# Altium schematic sheet Sheet1.SchDoc(Sheet1)
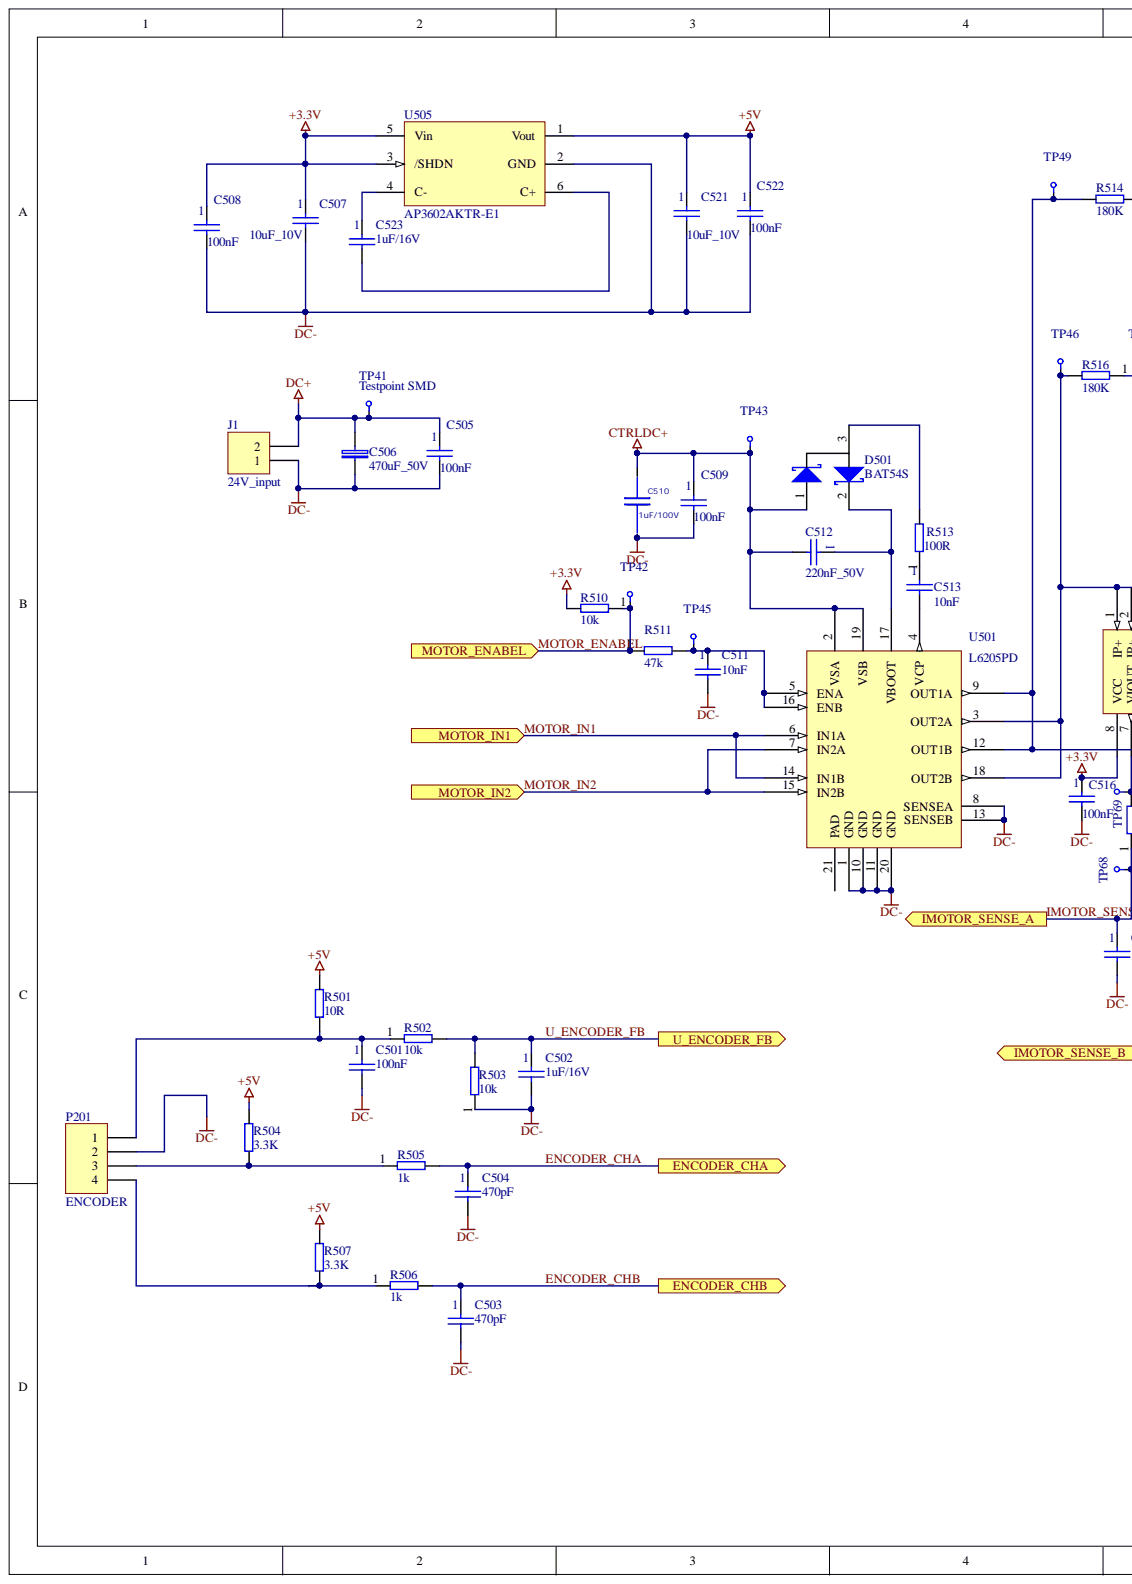
[diagram p0: Sheet1.SchDoc, left]
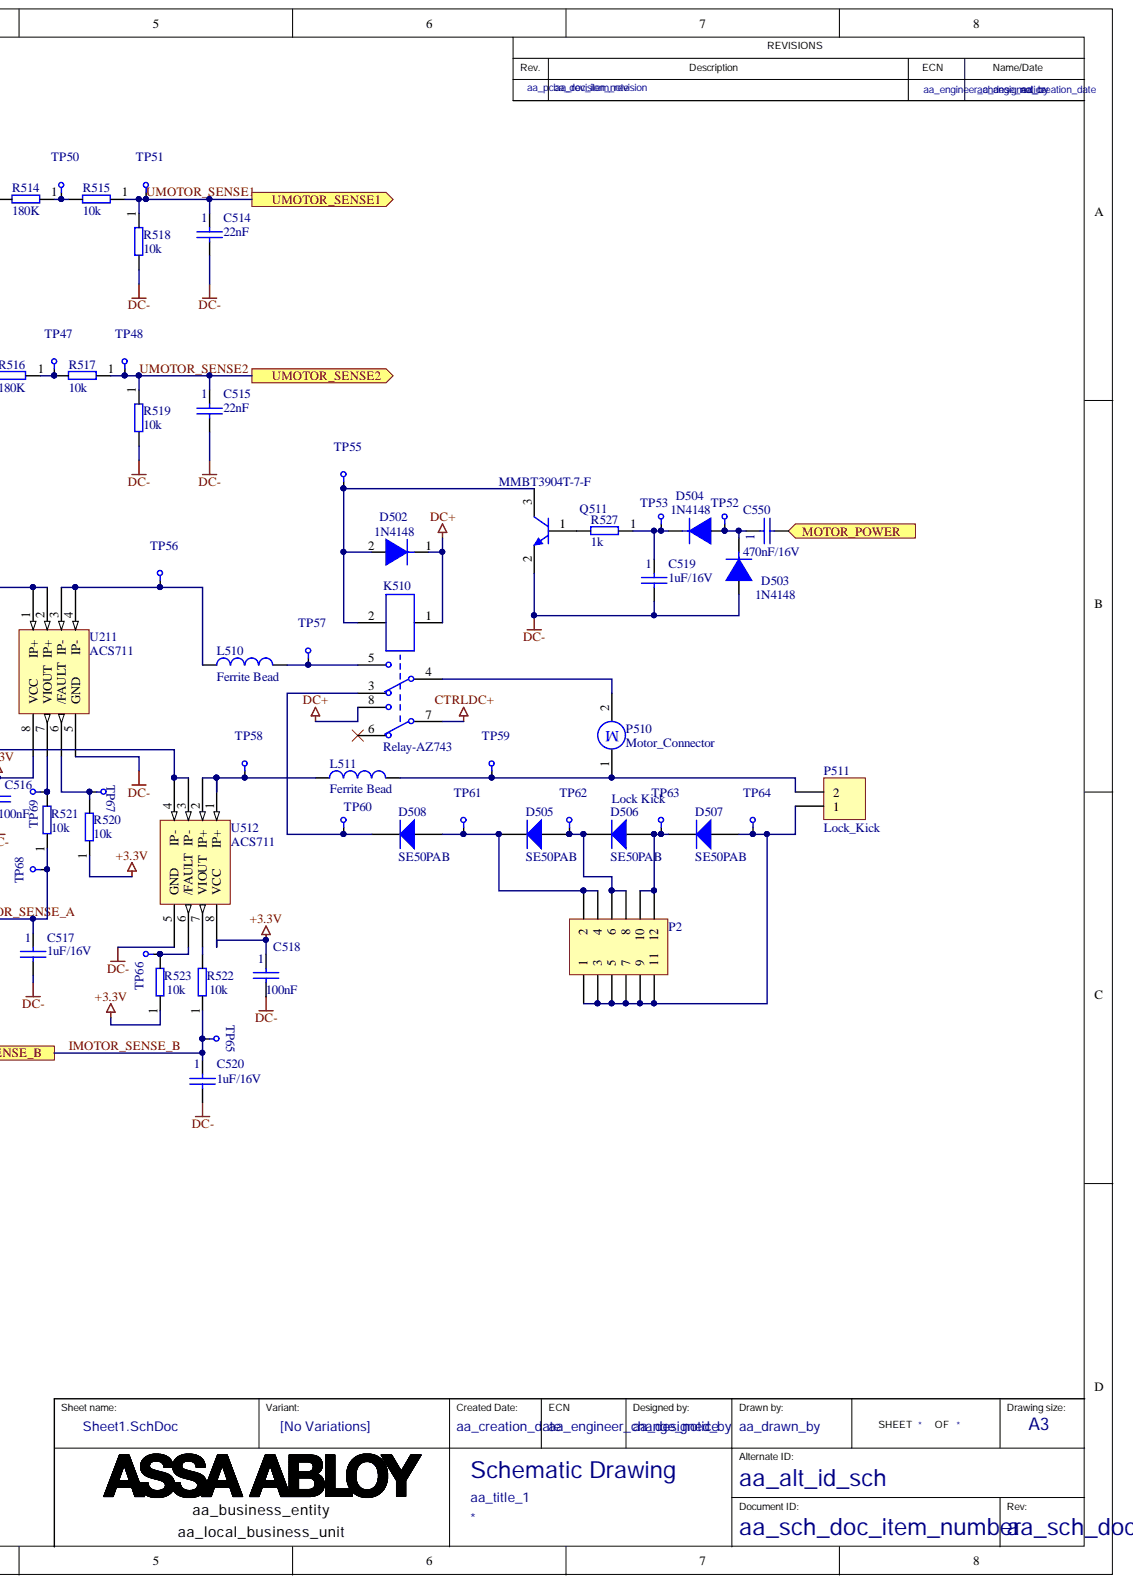
[diagram p1: Sheet1.SchDoc, right]

## Parts
C501  100nF  [CAPC1608X08N]
C502  1uF/16V  [CAPC1608X08N]
C503  470pF  [CAPC1608X08N]
C504  470pF  [CAPC1608X08N]
C505  100nF  [CAPC1608X08N]
C506  470uF_50V  [EC5/10]
C507  10uF_10V  [0603C/H]
C508  100nF  [CAPC1608X08N]
C509  100nF  [CAPC1608X08N]
C510  value 1uF/100V  [CAPR-RM7_5$5C$10_3X5_7]  (hidden comment: CAPR_RM7_5_1U/100V)
C511  10nF  [CAPC1608X08N]
C512  220nF_50V  [0603C/H]
C513  10nF  [CAPC1608X08N]
C514  22nF  [CAPC1608X08N]
C515  22nF  [CAPC1608X08N]
C516  100nF  [CAPC1608X08N]
C517  1uF/16V  [CAPC1608X08N]
C518  100nF  [CAPC1608X08N]
C519  1uF/16V  [CAPC1608X08N]
C520  1uF/16V  [CAPC1608X08N]
C521  10uF_10V  [0603C/H]
C522  100nF  [CAPC1608X08N]
C523  1uF/16V  [CAPC1608X08N]
C550  470nF/16V  [CAPC1608X08N]
D501  BAT54S  [SOT-23-3]
D502  1N4148  [SODFL250X110-2N]
D503  1N4148  [SODFL250X110-2N]
D504  1N4148  [SODFL250X110-2N]
D505  SE50PAB  [SMA-S1G]
D506  SE50PAB  [SMA-S1G]
D507  SE50PAB  [SMA-S1G]
D508  SE50PAB  [SMA-S1G]
J1  24V_input  [BP-VH-FB-B]
K510  Relay-AZ743  [Relay_AZ743]
L510  Ferrite Bead  [Ferrite_bead]
L511  Ferrite Bead  [Ferrite_bead]
P2  (no value)  [PCBComponent_1]
P201  ENCODER  [CON$5C$4P$5C$MICRO-F-1700566]
P510  Motor_Connector  [CON-TS-1X3-738667]
P511  Lock_Kick  [Molex_26-60-4020]
Q511  MMBT3904T-7-F  [SOT-523]
R501  10R  [RESC1608X05N]
R502  10k  [RESC1608X05N]
R503  10k  [RESC1608X05N]
R504  3.3K  [0603R/H]
R505  1k  [RESC1608X05N]
R506  1k  [RESC1608X05N]
R507  3.3K  [0603R/H]
R510  10k  [RESC1608X05N]
R511  47k  [RESC1608X05N]
R513  100R  [RESC1608X05N]
R514  180K  [0603R/H]
R515  10k  [RESC1608X05N]
R516  180K  [0603R/H]
R517  10k  [RESC1608X05N]
R518  10k  [RESC1608X05N]
R519  10k  [RESC1608X05N]
R520  10k  [RESC1608X05N]
R521  10k  [RESC1608X05N]
R522  10k  [RESC1608X05N]
R523  10k  [RESC1608X05N]
R527  1k  [RESC1608X05N]
TP41  Testpoint SMD  [PCB_PARTS_TESTPOINT100_SMD]  (no BOM)
TP42  Testpoint SMD  [PCB_PARTS_TESTPOINT100_SMD]  (no BOM)
TP43  Testpoint SMD  [PCB_PARTS_TESTPOINT100_SMD]  (no BOM)
TP45  Testpoint SMD  [PCB_PARTS_TESTPOINT100_SMD]  (no BOM)
TP46  Testpoint SMD  [PCB_PARTS_TESTPOINT100_SMD]  (no BOM)
TP47  Testpoint SMD  [PCB_PARTS_TESTPOINT100_SMD]  (no BOM)
TP48  Testpoint SMD  [PCB_PARTS_TESTPOINT100_SMD]  (no BOM)
TP49  Testpoint SMD  [PCB_PARTS_TESTPOINT100_SMD]  (no BOM)
TP50  Testpoint SMD  [PCB_PARTS_TESTPOINT100_SMD]  (no BOM)
TP51  Testpoint SMD  [PCB_PARTS_TESTPOINT100_SMD]  (no BOM)
TP52  Testpoint SMD  [PCB_PARTS_TESTPOINT100_SMD]  (no BOM)
TP53  Testpoint SMD  [PCB_PARTS_TESTPOINT100_SMD]  (no BOM)
TP55  Testpoint SMD  [PCB_PARTS_TESTPOINT100_SMD]  (no BOM)
TP56  Testpoint SMD  [PCB_PARTS_TESTPOINT100_SMD]  (no BOM)
TP57  Testpoint SMD  [PCB_PARTS_TESTPOINT100_SMD]  (no BOM)
TP58  Testpoint SMD  [PCB_PARTS_TESTPOINT100_SMD]  (no BOM)
TP59  Testpoint SMD  [PCB_PARTS_TESTPOINT100_SMD]  (no BOM)
TP60  Testpoint SMD  [PCB_PARTS_TESTPOINT100_SMD]  (no BOM)
TP61  Testpoint SMD  [PCB_PARTS_TESTPOINT100_SMD]  (no BOM)
TP62  Testpoint SMD  [PCB_PARTS_TESTPOINT100_SMD]  (no BOM)
TP63  Testpoint SMD  [PCB_PARTS_TESTPOINT100_SMD]  (no BOM)
TP64  Testpoint SMD  [PCB_PARTS_TESTPOINT100_SMD]  (no BOM)
TP65  Testpoint SMD  [PCB_PARTS_TESTPOINT100_SMD]  (no BOM)
TP66  Testpoint SMD  [PCB_PARTS_TESTPOINT100_SMD]  (no BOM)
TP67  Testpoint SMD  [PCB_PARTS_TESTPOINT100_SMD]  (no BOM)
TP68  Testpoint SMD  [PCB_PARTS_TESTPOINT100_SMD]  (no BOM)
TP69  Testpoint SMD  [PCB_PARTS_TESTPOINT100_SMD]  (no BOM)
U211  ACS711  [SOIC-8LC]
U501  L6205PD  [POWERSO20_Pad]
U505  AP3602AKTR-E1  [SOT95P280X145-6N]
U512  ACS711  [SOIC-8LC]

## Ports
ENCODER_CHA, ENCODER_CHB, IMOTOR_SENSE_A, IMOTOR_SENSE_B, MOTOR_ENABEL, MOTOR_IN1, MOTOR_IN2, MOTOR_POWER, U_ENCODER_FB, UMOTOR_SENSE1, UMOTOR_SENSE2

## Nets
+3.3V: C507.1 C508.1 C516.1 C518.1 R510.2 R520.1 R523.1 U211.8(VCC) U505.3(/SHDN) U505.5(Vin) U512.8(VCC)
+5V: C521.1 C522.1 R501.2 R504.1 R507.2 U505.1(Vout)
CTRLDC+: C509.1 C510.1 C512.2 D501.1 K510.7 TP43.1 U501.2(VSA) U501.19(VSB)
DC+: C505.1 C506.1 D502.1 J1.2 K510.1 K510.8 TP41.1
DC-: C501.2 C502.2 C503.2 C504.2 C505.2 C506.2 C507.2 C508.2 C509.2 C510.2 C511.2 C514.2 C515.2 C516.2 C517.2 C518.2 C519.2 C520.2 C521.2 C522.2 D503.2 J1.1 P201.2 Q511.2 R503.1 R518.2 R519.2 U211.5(GND) U501.1(GND) U501.8(SENSEA) U501.10(GND) U501.11(GND) U501.13(SENSEB) U501.20(GND) U505.2(GND) U512.5(GND)
ENCODER_CHA: C504.1 R505.2 ; port ENCODER_CHA
ENCODER_CHB: C503.1 R506.2 ; port ENCODER_CHB
IMOTOR_SENSE_A: C517.1 R521.1 TP68.1 ; port IMOTOR_SENSE_A
IMOTOR_SENSE_B: C520.1 R522.1 TP65.1 ; port IMOTOR_SENSE_B
MOTOR_ENABEL: R510.1 R511.1 TP42.1 ; port MOTOR_ENABEL
MOTOR_IN1: U501.6(IN1A) U501.14(IN1B) ; port MOTOR_IN1
MOTOR_IN2: U501.7(IN2A) U501.15(IN2B) ; port MOTOR_IN2
MOTOR_POWER: C550.2 ; port MOTOR_POWER
U_ENCODER_FB: C502.1 R502.2 R503.2 ; port U_ENCODER_FB
UMOTOR_SENSE1: C514.1 R515.1 R518.1 TP51.1 ; port UMOTOR_SENSE1
UMOTOR_SENSE2: C515.1 R517.1 R519.1 TP48.1 ; port UMOTOR_SENSE2
NetC501_1: C501.1 P201.1 R501.1 R502.1
NetC511_1: C511.1 R511.2 TP45.1 U501.5(ENA) U501.16(ENB)
NetC512_1: C512.1 D501.2 U501.17(VBOOT)
NetC513_1: C513.1 R513.1
NetC513_2: C513.2 U501.4(VCP)
NetC519_1: C519.1 D504.1 R527.1 TP53.1
NetC523_1: C523.1 U505.4(C-)
NetC523_2: C523.2 U505.6(C+)
NetC550_1: C550.1 D503.1 D504.2 TP52.1
NetD501_3: D501.3 R513.2
NetD502_2: D502.2 K510.2 Q511.3 TP55.1
NetD505_1: D505.1 D506.2 P2.6 P2.8 TP62.1
NetD505_2: D505.2 D508.1 P2.2 P2.4 TP61.1
NetD506_1: D506.1 D507.2 P2.10 P2.12 TP63.1
NetD507_1: D507.1 P2.1 P2.3 P2.5 P2.7 P2.9 P2.11 P511.1 TP64.1
NetD508_2: D508.2 K510.3 TP60.1
NetK510_4: K510.4 P510.2
NetK510_5: K510.5 L510.2 TP57.1
NetL510_1: L510.1 TP56.1 U211.3(IP-) U211.4(IP-)
NetL511_1: L511.1 TP58.1 U512.1(IP+) U512.2(IP+)
NetL511_2: L511.2 P510.1 P511.2 TP59.1
NetP201_3: P201.3 R504.2 R505.1
NetP201_4: P201.4 R506.1 R507.1
NetQ511_1: Q511.1 R527.2
NetR514_1: R514.1 R515.2 TP50.1
NetR514_2: R514.2 TP49.1 U501.9(OUT1A) U501.12(OUT1B) U512.3(IP-) U512.4(IP-)
NetR516_1: R516.1 R517.2 TP47.1
NetR516_2: R516.2 TP46.1 U211.1(IP+) U211.2(IP+) U501.3(OUT2A) U501.18(OUT2B)
NetR520_2: R520.2 TP67.1 U211.6(/FAULT)
NetR521_2: R521.2 TP69.1 U211.7(VIOUT)
NetR522_2: R522.2 U512.7(VIOUT)
NetR523_2: R523.2 TP66.1 U512.6(/FAULT)

Not connected: K510 6; U501 21(PAD)

## Library data of the parts
C501 = 715219: Capacitor; APPROVED_MPN_05: 223878615649 ,X7R, 0603, 10%; APPROVED_MPN_04: CC0603KRX7R7BB104; APPROVED_MPN_03: 0603YC104KAT ,X7R,0603,10%; APPROVED_MPN_02: GRM188R71C104KA01; APPROVED_MPN_01: CL10B104KO8NNN; APPROVED_MANUFACTURER_05: Yageo Phy; APPROVED_MANUFACTURER_04: YAGEO; APPROVED_MANUFACTURER_03: AVX KYOCERA; APPROVED_MANUFACTURER_02: MURATA; APPROVED_MANUFACTURER_01: SAMSUNG; PHYSICALPACKAGE: EIA0603; MOUNTEDTYPE: SMD; Database Table Name: Capacitors_Ceramic; VALUE: 100nF; TOLERANCE: -; PROTOTYPE_MPN_01: -; PROTOTYPE_MANUFACTURER_01: -; POWERRATING: -; PARTNUMBER: 715219; PARTNAME: Capacitor; Library Name: AltiumLib_Database.DbLib; Component Kind: Standard; APPROVED_MPN_08: -; APPROVED_MPN_07: -; APPROVED_MPN_06: -; APPROVED_MANUFACTURER_08: -; APPROVED_MANUFACTURER_07: -; APPROVED_MANUFACTURER_06: -
C502 C517 C519 C520 C523 = 1700546: Capacitor; APPROVED_MPN_02: GRM188R71C105KA12D; APPROVED_MPN_01: C1608X7R1C105K080AC; APPROVED_MANUFACTURER_02: MURATA; APPROVED_MANUFACTURER_01: TDK; PHYSICALPACKAGE: EIA0603; MOUNTEDTYPE: SMD; Database Table Name: Capacitors_Ceramic; VALUE: 1uF/16V; TOLERANCE: -; PROTOTYPE_MPN_01: -; PROTOTYPE_MANUFACTURER_01: -; POWERRATING: -; PARTNUMBER: 1700546; PARTNAME: Capacitor; Library Name: AltiumLib_Database.DbLib; Component Kind: Standard; APPROVED_MPN_08: -; APPROVED_MPN_07: -; APPROVED_MPN_06: -; APPROVED_MPN_05: -; APPROVED_MPN_04: -; APPROVED_MPN_03: -; APPROVED_MANUFACTURER_08: -; APPROVED_MANUFACTURER_07: -; APPROVED_MANUFACTURER_06: -; APPROVED_MANUFACTURER_05: -; APPROVED_MANUFACTURER_04: -; APPROVED_MANUFACTURER_03: -
C503 C504 = 1700536: Capacitor; APPROVED_MPN_01: C1608JB1H471K  RoHS; APPROVED_MANUFACTURER_01: TDK; PHYSICALPACKAGE: EIA0603; MOUNTEDTYPE: SMD; Database Table Name: Capacitors_Ceramic; VALUE: 470pF; TOLERANCE: -; PROTOTYPE_MPN_01: -; PROTOTYPE_MANUFACTURER_01: -; POWERRATING: -; PARTNUMBER: 1700536; PARTNAME: Capacitor; Library Name: AltiumLib_Database.DbLib; Component Kind: Standard; APPROVED_MPN_08: -; APPROVED_MPN_07: -; APPROVED_MPN_06: -; APPROVED_MPN_05: -; APPROVED_MPN_04: -; APPROVED_MPN_03: -; APPROVED_MPN_02: -; APPROVED_MANUFACTURER_08: -; APPROVED_MANUFACTURER_07: -; APPROVED_MANUFACTURER_06: -; APPROVED_MANUFACTURER_05: -; APPROVED_MANUFACTURER_04: -; APPROVED_MANUFACTURER_03: -; APPROVED_MANUFACTURER_02: -
C505 C508 C509 C516 C518 C522 = 1700540: Capacitor; APPROVED_MPN_06: 0603B104K500CT; APPROVED_MPN_05: CC0603K_9104; APPROVED_MPN_04: C0603C104K5RAC; APPROVED_MPN_03: 06035C104KAT_A; APPROVED_MPN_02: GRM188R71H104K; APPROVED_MPN_01: C1608X7R1H104K; APPROVED_MANUFACTURER_06: WALSIN; APPROVED_MANUFACTURER_05: YAGEO; APPROVED_MANUFACTURER_04: KEMET; APPROVED_MANUFACTURER_03: AVX; APPROVED_MANUFACTURER_02: MURATA; APPROVED_MANUFACTURER_01: TDK; PHYSICALPACKAGE: EIA0603; MOUNTEDTYPE: SMD; Database Table Name: Capacitors_Ceramic; VALUE: 100nF; TOLERANCE: -; PROTOTYPE_MPN_01: -; PROTOTYPE_MANUFACTURER_01: -; POWERRATING: -; PARTNUMBER: 1700540; PARTNAME: Capacitor; Library Name: AltiumLib_Database.DbLib; Component Kind: Standard; APPROVED_MPN_08: -; APPROVED_MPN_07: -; APPROVED_MANUFACTURER_08: -; APPROVED_MANUFACTURER_07: -
C506 = Capacitor-Polarized: Capaitor pol; Library Name: Capacitor-Polarized.SchLib; Component Kind: Standard
C507 C512 C521 = Capacitor-NonPolarized: 0603; Library Name: Capacitor-NonPolarized.SchLib; Component Kind: Standard
C510 = CAPR_RM7_5_1U/100V: PART DESC: Polyester Film Capacitor RM 7.5 mm; BESAM PART NO: 1701429; PCB DECAL: CAPR-RM7_5\10_3X5_7; MFG: WIMA MKS 4; Component Kind: Standard; CREATED BY: ANDERS HJELM
C511 C513 = 1700542: Capacitor; APPROVED_MPN_01: C1608CH1E103J  RoHS; APPROVED_MANUFACTURER_01: TDK; PHYSICALPACKAGE: EIA0603; MOUNTEDTYPE: SMD; Database Table Name: Capacitors_Ceramic; VALUE: 10nF; TOLERANCE: -; PROTOTYPE_MPN_01: -; PROTOTYPE_MANUFACTURER_01: -; POWERRATING: -; PARTNUMBER: 1700542; PARTNAME: Capacitor; Library Name: AltiumLib_Database.DbLib; Component Kind: Standard; APPROVED_MPN_08: -; APPROVED_MPN_07: -; APPROVED_MPN_06: -; APPROVED_MPN_05: -; APPROVED_MPN_04: -; APPROVED_MPN_03: -; APPROVED_MPN_02: -; APPROVED_MANUFACTURER_08: -; APPROVED_MANUFACTURER_07: -; APPROVED_MANUFACTURER_06: -; APPROVED_MANUFACTURER_05: -; APPROVED_MANUFACTURER_04: -; APPROVED_MANUFACTURER_03: -; APPROVED_MANUFACTURER_02: -
C514 C515 = 715500: Capacitor; APPROVED_MPN_04: 2238586_5641 (RoHS); APPROVED_MPN_03: GRM188R71H223KA01_ (RoHS); APPROVED_MPN_02: C0603C223K5RAC (RoHS); APPROVED_MPN_01: 06035C223KAT2A (RoHS); APPROVED_MANUFACTURER_04: Yageo Phycomp.; APPROVED_MANUFACTURER_03: MURATA; APPROVED_MANUFACTURER_02: KEMET; APPROVED_MANUFACTURER_01: AVX; PHYSICALPACKAGE: EIA0603; MOUNTEDTYPE: SMD; Database Table Name: Capacitors_Ceramic; VALUE: 22nF; TOLERANCE: -; PROTOTYPE_MPN_01: -; PROTOTYPE_MANUFACTURER_01: -; POWERRATING: -; PARTNUMBER: 715500; PARTNAME: Capacitor; Library Name: AltiumLib_Database.DbLib; Component Kind: Standard; APPROVED_MPN_08: -; APPROVED_MPN_07: -; APPROVED_MPN_06: -; APPROVED_MPN_05: -; APPROVED_MANUFACTURER_08: -; APPROVED_MANUFACTURER_07: -; APPROVED_MANUFACTURER_06: -; APPROVED_MANUFACTURER_05: -
C550 = 1700545: Capacitor; PARTNAME: GRM188R71C474KA88D; APPROVED_MPN_03: CL10B474KO8NNN_ (RoHS); APPROVED_MPN_01: C1608JB1C474K (RoHS); APPROVED_MANUFACTURER_03: SAMSUNG; APPROVED_MANUFACTURER_02: Murata; APPROVED_MANUFACTURER_01: TDK; TOLERANCE: 10%; PHYSICALPACKAGE: EIA0603; MOUNTEDTYPE: SMD; Database Table Name: Capacitors_Ceramic; VALUE: 470nF/16V; PROTOTYPE_MPN_01: -; PROTOTYPE_MANUFACTURER_01: -; POWERRATING: -; PARTNUMBER: 1700545; Library Name: AltiumLib_Database.DbLib; Component Kind: Standard; APPROVED_MPN_08: -; APPROVED_MPN_07: -; APPROVED_MPN_06: -; APPROVED_MPN_05: -; APPROVED_MPN_04: -; APPROVED_MPN_02: GRM188R71C474KA88D; APPROVED_MANUFACTURER_08: -; APPROVED_MANUFACTURER_07: -; APPROVED_MANUFACTURER_06: -; APPROVED_MANUFACTURER_05: -; APPROVED_MANUFACTURER_04: -
D501 = BAT54S: Schottky Diode; MPN: ON SEMICONDUCTOR, BAT54SLT1G; Article: 715359-1.0; Simulation: SKYDIODE; Signal Integrity: D Schottky; Published: 8-Jun-2000; PackageReference: SMB; PackageDescription: DO-214-AA/SMB; 2 C-Bend Leads; Body 5.3 x 3.6 mm, inc. leads (LxW); Library Name: MCU_Sch_Lib.SchLib; LatestRevisionNote: Re-released for DXP Platform.; LatestRevisionDate: 17-Jul-2002; Component Kind: Standard; Code_JEDEC: DO-214-AA; Publisher: Altium Limited
D502-D504 = 1703227: Diode; PARTNUMBER: 1701540; APPROVED_MANUFACTURER_01: NXP; PHYSICALPACKAGE: SOD-323; MOUNTEDTYPE: SMD; Database Table Name: Diodes_Rectifiers; VALUE: 1N4148; TOLERANCE: -; PROTOTYPE_MPN_01: -; PROTOTYPE_MANUFACTURER_01: -; POWERRATING: -; PARTNAME: 1N4148; Library Name: AltiumLib_Database.DbLib; Component Kind: Standard; APPROVED_MPN_08: -; APPROVED_MPN_07: -; APPROVED_MPN_06: -; APPROVED_MPN_05: -; APPROVED_MPN_04: -; APPROVED_MPN_03: -; APPROVED_MPN_02: -; APPROVED_MPN_01: 1N4148; APPROVED_MANUFACTURER_08: -; APPROVED_MANUFACTURER_07: -; APPROVED_MANUFACTURER_06: -; APPROVED_MANUFACTURER_05: -; APPROVED_MANUFACTURER_04: -; APPROVED_MANUFACTURER_03: -; APPROVED_MANUFACTURER_02: -
D505-D508 = Diode: Default Diode; MPN: VISHAY SE50PAB; Article: 1700744-2.0; Simulation: DIODE; Signal Integrity: Diode; Published: 8-Jun-2000; PackageVersion: Sep-1996; PackageReference: SMC; PackageDescription: DO-214-AB/SMC; 2 C-Bend Leads; Body 7.9 x 5.9 mm, inc. leads (LxW); Library Name: Miscellaneous Devices.IntLib; LatestRevisionNote: Re-released for DXP Platform.; LatestRevisionDate: 17-Jul-2002; Component Kind: Standard; Code_JEDEC: DO-214-AB; Publisher: Altium Limited
J1 P511 = Header2_P3.96: Header, 2-Pin; MPN: Molex, 26-60-4020, (or 26-60-5020); Article: 1701458-3.0; Signal Integrity: Connector; Library Name: MCU_Sch_Lib.SchLib; LatestRevisionNote: Re-released for DXP Platform.; LatestRevisionDate: 17-Jul-2002; Component Kind: Standard; Publisher: Altium Limited
K510 = Relay-AZ743: Dual-Pole Dual-Throw Relay; MPN: Zettler, AZ7431-2C-24D(108); Published: 24-Mar-1999; PackageReference: DIP-P8; PackageDescription: Relay; 8 Leads; Library Name: PCB_Project_SCH.SchLib; LatestRevisionNote: Re-released for DXP Platform.; LatestRevisionDate: 17-Jul-2002; Component Kind: Standard; Publisher: Altium Limited
L510 L511 = Inductor: MPN: Murata, BL02RN2R3J2B, BL02RN2R3N1A; Code_IEC: 1005; Article: 1702716-1.0; Simulation: INDUCTOR; Signal Integrity: Inductor; Published: 8-Jun-2000; PackageReference: 0402-A; PackageDescription: Chip Inductor; Library Name: Miscellaneous Devices.IntLib; LatestRevisionNote: IPC-7351 Footprint Added.; LatestRevisionDate: 29-May-2009; Description: Inductor; Component Kind: Standard; Code_JEITA: 0402; Publisher: Altium Limited
P2 = Header 6X2: Header, 6-Pin, Dual row; MPN: Test Point 6x2; Signal Integrity: Connector; Library Name: Miscellaneous Connectors.IntLib; LatestRevisionNote: Re-released for DXP Platform.; LatestRevisionDate: 17-Jul-2002; Component Kind: Standard; Publisher: Altium Limited
P201 = Encoder Connector: Header, 4-Pin; MPN: MOLEX, 43045-0400 (RoHS); Article: 738714-3.0; Signal Integrity: Connector; Library Name: MCU_Sch_Lib.SchLib; LatestRevisionNote: Re-released for DXP Platform.; LatestRevisionDate: 17-Jul-2002; Component Kind: Standard; Publisher: Altium Limited
P510 = Motor_Connector: Motor, General Kind; MPN: MOLEX / 5569-02A,39-30-0020 (RoHS); Article: 738712-3.0; Published: 24-Mar-1999; PackageReference: RB5-10.5; PackageDescription: Capacitor (Radial); 2 Leads; Library Name: MCU_Sch_Lib.SchLib; LatestRevisionNote: Re-released for DXP Platform.; LatestRevisionDate: 17-Jul-2002; Component Kind: Standard; Publisher: Altium Limited
Q511 = 1703255: Transistor, NPN; APPROVED_MANUFACTURER_01: Diodes; PHYSICALPACKAGE: SOT523; MOUNTEDTYPE: SMD; Database Table Name: Transistors_Bipolar; VALUE: MMBT3904T-7-F; TOLERANCE: -; PROTOTYPE_MPN_01: -; PROTOTYPE_MANUFACTURER_01: -; POWERRATING: -; PARTNUMBER: 1703255; PARTNAME: MMBT3904T-7-F; Library Name: AltiumLib_Database.DbLib; Component Kind: Standard; APPROVED_MPN_08: -; APPROVED_MPN_07: -; APPROVED_MPN_06: -; APPROVED_MPN_05: -; APPROVED_MPN_04: -; APPROVED_MPN_03: -; APPROVED_MPN_02: -; APPROVED_MPN_01: MMBT3904T-7-F; APPROVED_MANUFACTURER_08: -; APPROVED_MANUFACTURER_07: -; APPROVED_MANUFACTURER_06: -; APPROVED_MANUFACTURER_05: -; APPROVED_MANUFACTURER_04: -; APPROVED_MANUFACTURER_03: -; APPROVED_MANUFACTURER_02: -
R501 = 714740: Resistor; APPROVED_MPN_05: MCR03-X-F-10R (RoHS); APPROVED_MPN_04: RK73H1JTTD10RF (RoHS); APPROVED_MPN_03: RC22 ,2322704X1009L (RoHS); APPROVED_MPN_02: MCT0603-50,1%,10R, Lead Free (RoHS); APPROVED_MPN_01: D11, 10R/1% ET_ E3 (ROHS); APPROVED_MANUFACTURER_05: ROHM; APPROVED_MANUFACTURER_04: KOA; APPROVED_MANUFACTURER_03: Yageo Phycomp; APPROVED_MANUFACTURER_02: Vishay; TOLERANCE: 1%; POWERRATING: 0.063W; PHYSICALPACKAGE: EIA0603; MOUNTEDTYPE: SMD; Database Table Name: Resistors; VALUE: 10R; PROTOTYPE_MPN_01: -; PROTOTYPE_MANUFACTURER_01: -; PARTNUMBER: 714740; PARTNAME: 10R; Library Name: AltiumLib_Database.DbLib; Component Kind: Standard; APPROVED_MPN_08: -; APPROVED_MPN_07: -; APPROVED_MPN_06: -; APPROVED_MANUFACTURER_08: -; APPROVED_MANUFACTURER_07: -; APPROVED_MANUFACTURER_06: -; APPROVED_MANUFACTURER_01: Vishay
R502 R503 R510 R515 R517-R523 = 714811: Resistor; APPROVED_MPN_05: MCR03-X-F-10k (RoHS); APPROVED_MPN_04: RK73H1JTTD10kF (RoHS); APPROVED_MPN_03: RC22 ,2322704X11003L (RoHS); APPROVED_MPN_02: MCT0603-50,1%,10k ET_ E3 (RoHS); APPROVED_MPN_01: D11, 10k/1% ET_ E3 (RoHS); APPROVED_MANUFACTURER_05: ROHM; APPROVED_MANUFACTURER_04: KOA; APPROVED_MANUFACTURER_03: Yageo; APPROVED_MANUFACTURER_02: Vishay; TOLERANCE: 1%; POWERRATING: 0.063W; PHYSICALPACKAGE: EIA0603; MOUNTEDTYPE: SMD; Database Table Name: Resistors; VALUE: 10k; PROTOTYPE_MPN_01: -; PROTOTYPE_MANUFACTURER_01: -; PARTNUMBER: 714811; PARTNAME: 10k; Library Name: AltiumLib_Database.DbLib; Component Kind: Standard; APPROVED_MPN_08: -; APPROVED_MPN_07: -; APPROVED_MPN_06: -; APPROVED_MANUFACTURER_08: -; APPROVED_MANUFACTURER_07: -; APPROVED_MANUFACTURER_06: -; APPROVED_MANUFACTURER_01: Vishay
R504 R507 R514 R516 = Resistor: Library Name: Resistor.SchLib; Description: Resistor; Component Kind: Standard
R505 R506 R527 = 714787: Resistor; APPROVED_MPN_05: MCR03-X-F-1k (RoHS); APPROVED_MPN_04: RK73H1JTTD1kF (RoHS); APPROVED_MPN_03: RC22, 2322704X11002L (RoHS); APPROVED_MPN_02: MCT0603-50,1%,1k ET_ E3 (RoHS); APPROVED_MPN_01: D11, 1k/1%, ET_ E3(RoHS); APPROVED_MANUFACTURER_05: ROHM; APPROVED_MANUFACTURER_04: KOA; APPROVED_MANUFACTURER_03: Yageo; APPROVED_MANUFACTURER_02: BEYSCHLAG; APPROVED_MANUFACTURER_01: Vishay; TOLERANCE: 1%; POWERRATING: 0.063W; PHYSICALPACKAGE: EIA0603; MOUNTEDTYPE: SMD; Database Table Name: Resistors; VALUE: 1k; PROTOTYPE_MPN_01: -; PROTOTYPE_MANUFACTURER_01: -; PARTNUMBER: 714787; PARTNAME: 1k; Library Name: AltiumLib_Database.DbLib; Component Kind: Standard; APPROVED_MPN_08: -; APPROVED_MPN_07: -; APPROVED_MPN_06: -; APPROVED_MANUFACTURER_08: -; APPROVED_MANUFACTURER_07: -; APPROVED_MANUFACTURER_06: -
R511 = 714827: Resistor; APPROVED_MPN_05: MCT0603-50,1%,47k Lead Free (RoHS); APPROVED_MPN_04: MCR03-X-F-47k (RoHS); APPROVED_MPN_03: RK73H1JTTD47kF (RoHS); APPROVED_MPN_02: RC22H,2322704X4703L (RoHS); APPROVED_MPN_01: D11, 47k/1% ET_ E3 (RoHS); APPROVED_MANUFACTURER_05: BEYSCHLAG; APPROVED_MANUFACTURER_04: ROHM; APPROVED_MANUFACTURER_03: KOA; APPROVED_MANUFACTURER_02: Yageo; APPROVED_MANUFACTURER_01: OKAB ROE; TOLERANCE: 1%; POWERRATING: 0.063W; PHYSICALPACKAGE: EIA0603; MOUNTEDTYPE: SMD; Database Table Name: Resistors; VALUE: 47k; PROTOTYPE_MPN_01: -; PROTOTYPE_MANUFACTURER_01: -; PARTNUMBER: 714827; PARTNAME: 47k; Library Name: AltiumLib_Database.DbLib; Component Kind: Standard; APPROVED_MPN_08: -; APPROVED_MPN_07: -; APPROVED_MPN_06: -; APPROVED_MANUFACTURER_08: -; APPROVED_MANUFACTURER_07: -; APPROVED_MANUFACTURER_06: -
R513 = 714764: Resistor; APPROVED_MPN_05: MCT0603-50,1%,100R; APPROVED_MPN_04: MCR03-X-F-100R; APPROVED_MPN_03: RK73H1JTD100RF; APPROVED_MPN_02: RC22H,2322704X11001; APPROVED_MPN_01: D11, 100R/1%; APPROVED_MANUFACTURER_05: BEYSCHLAG; APPROVED_MANUFACTURER_04: ROHM; APPROVED_MANUFACTURER_03: KOA; APPROVED_MANUFACTURER_02: PHILIPS; APPROVED_MANUFACTURER_01: OKAB ROE; TOLERANCE: 1%; POWERRATING: 0.063W; PHYSICALPACKAGE: EIA0603; MOUNTEDTYPE: SMD; Database Table Name: Resistors; VALUE: 100R; PROTOTYPE_MPN_01: -; PROTOTYPE_MANUFACTURER_01: -; PARTNUMBER: 714764; PARTNAME: 100R; Library Name: AltiumLib_Database.DbLib; Component Kind: Standard; APPROVED_MPN_08: -; APPROVED_MPN_07: -; APPROVED_MPN_06: -; APPROVED_MANUFACTURER_08: -; APPROVED_MANUFACTURER_07: -; APPROVED_MANUFACTURER_06: -
TP41-TP43 TP45-TP53 TP55-TP69 = 1006: Testpoint; PHYSICALPACKAGE: 1mm SMD; Database Table Name: PCB_Parts; VALUE: Testpoint SMD; TOLERANCE: -; PROTOTYPE_MPN_01: -; PROTOTYPE_MANUFACTURER_01: -; POWERRATING: -; PARTNUMBER: 1006; PARTNAME: -; MOUNTEDTYPE: -; Library Name: AltiumLib_Database.DbLib; APPROVED_MPN_08: -; APPROVED_MPN_07: -; APPROVED_MPN_06: -; APPROVED_MPN_05: -; APPROVED_MPN_04: -; APPROVED_MPN_03: -; APPROVED_MPN_02: -; APPROVED_MPN_01: -; APPROVED_MANUFACTURER_08: -; APPROVED_MANUFACTURER_07: -; APPROVED_MANUFACTURER_06: -; APPROVED_MANUFACTURER_05: -; APPROVED_MANUFACTURER_04: -; APPROVED_MANUFACTURER_03: -; APPROVED_MANUFACTURER_02: -; APPROVED_MANUFACTURER_01: -
U211 U512 = ACS711: MPN: Allegro, ACS711ELCTR-12AB-T; Article: Replace 1701442-1.0; Library Name: PCB_Sch_06.SCHLIB; Component Kind: Standard
U501 = L6205PD: Motor Driver; MPN: ST,L6205PD; Library Name: PCB_Sch_06.SCHLIB; Component Kind: Standard
U505 = 1703736: 5V Charge pump regulator; APPROVED_MANUFACTURER_01: Diodes Inc.; PHYSICALPACKAGE: SOT23-6; MOUNTEDTYPE: SMD; Database Table Name: IC_PowerManagement; VALUE: AP3602AKTR-E1; TOLERANCE: -; PROTOTYPE_MPN_01: -; PROTOTYPE_MANUFACTURER_01: -; POWERRATING: -; PARTNUMBER: 1703736; PARTNAME: AP3602AKTR-E1; Library Name: AltiumLib_Database.DbLib; Component Kind: Standard; APPROVED_MPN_08: -; APPROVED_MPN_07: -; APPROVED_MPN_06: -; APPROVED_MPN_05: -; APPROVED_MPN_04: -; APPROVED_MPN_03: -; APPROVED_MPN_02: -; APPROVED_MPN_01: AP3602AKTR-E1; APPROVED_MANUFACTURER_08: -; APPROVED_MANUFACTURER_07: -; APPROVED_MANUFACTURER_06: -; APPROVED_MANUFACTURER_05: -; APPROVED_MANUFACTURER_04: -; APPROVED_MANUFACTURER_03: -; APPROVED_MANUFACTURER_02: -
